# Altium schematic sheet SuperCapV2.0.SchDoc
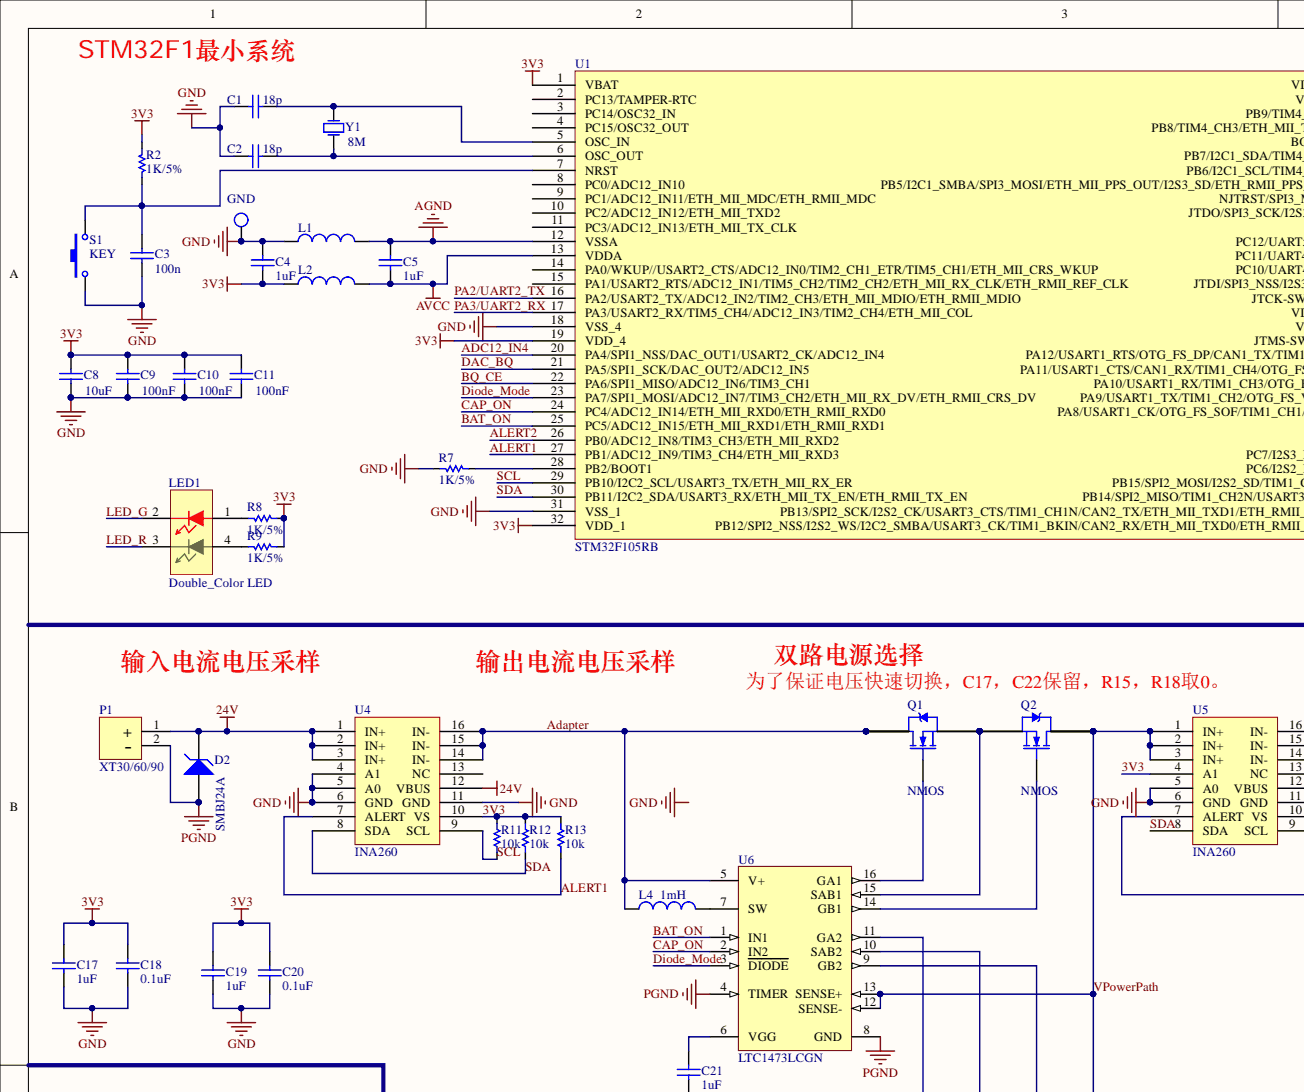
[diagram p0: SuperCapV2.0.SchDoc, top left]
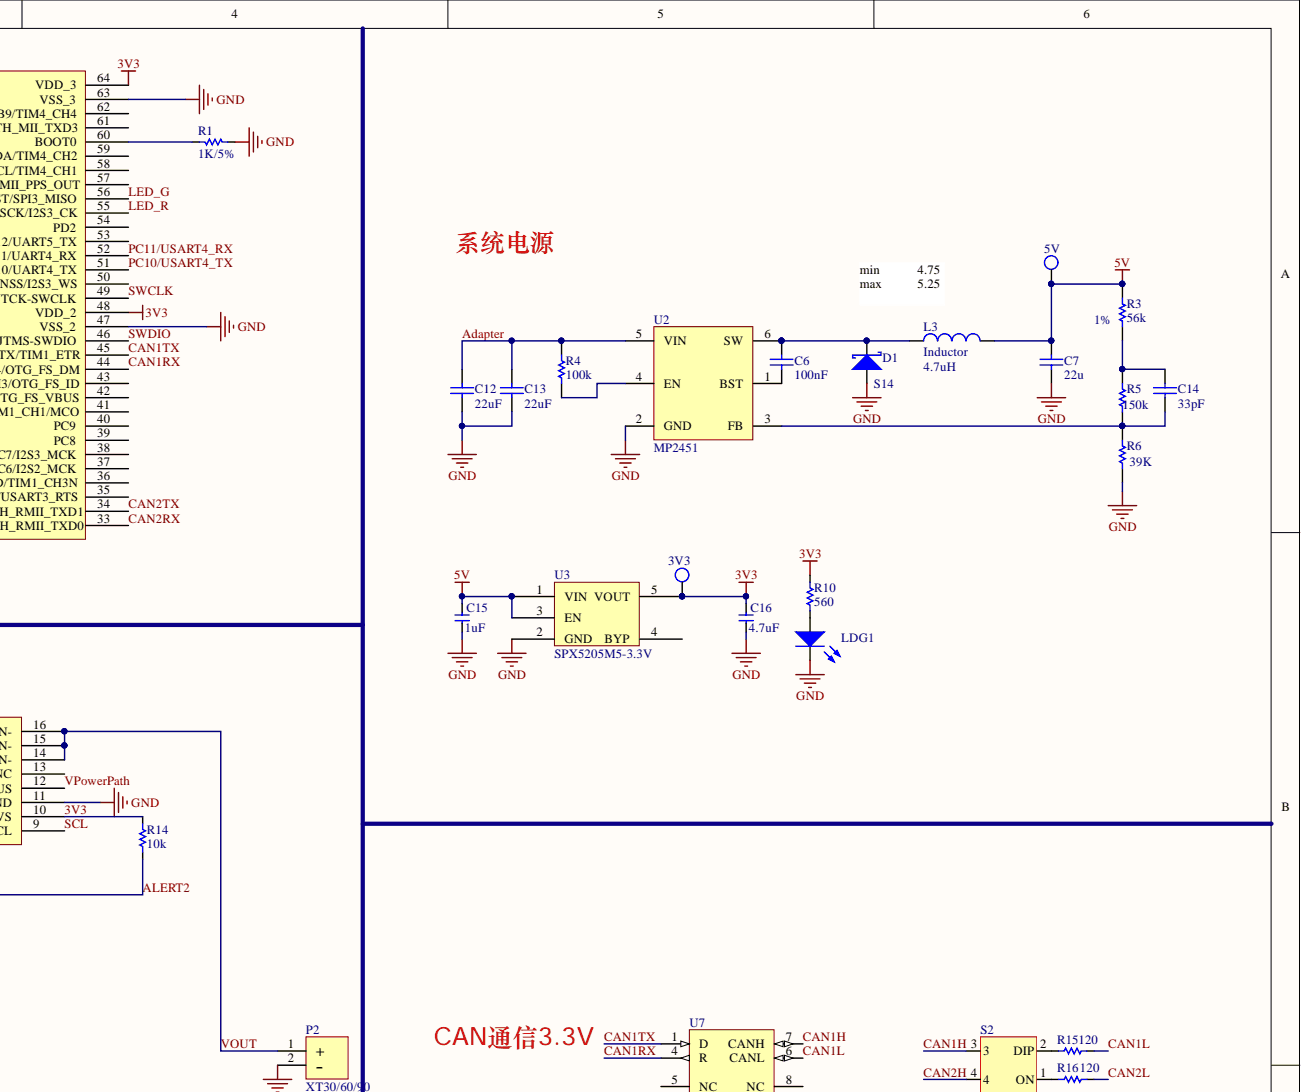
[diagram p1: SuperCapV2.0.SchDoc, top right]
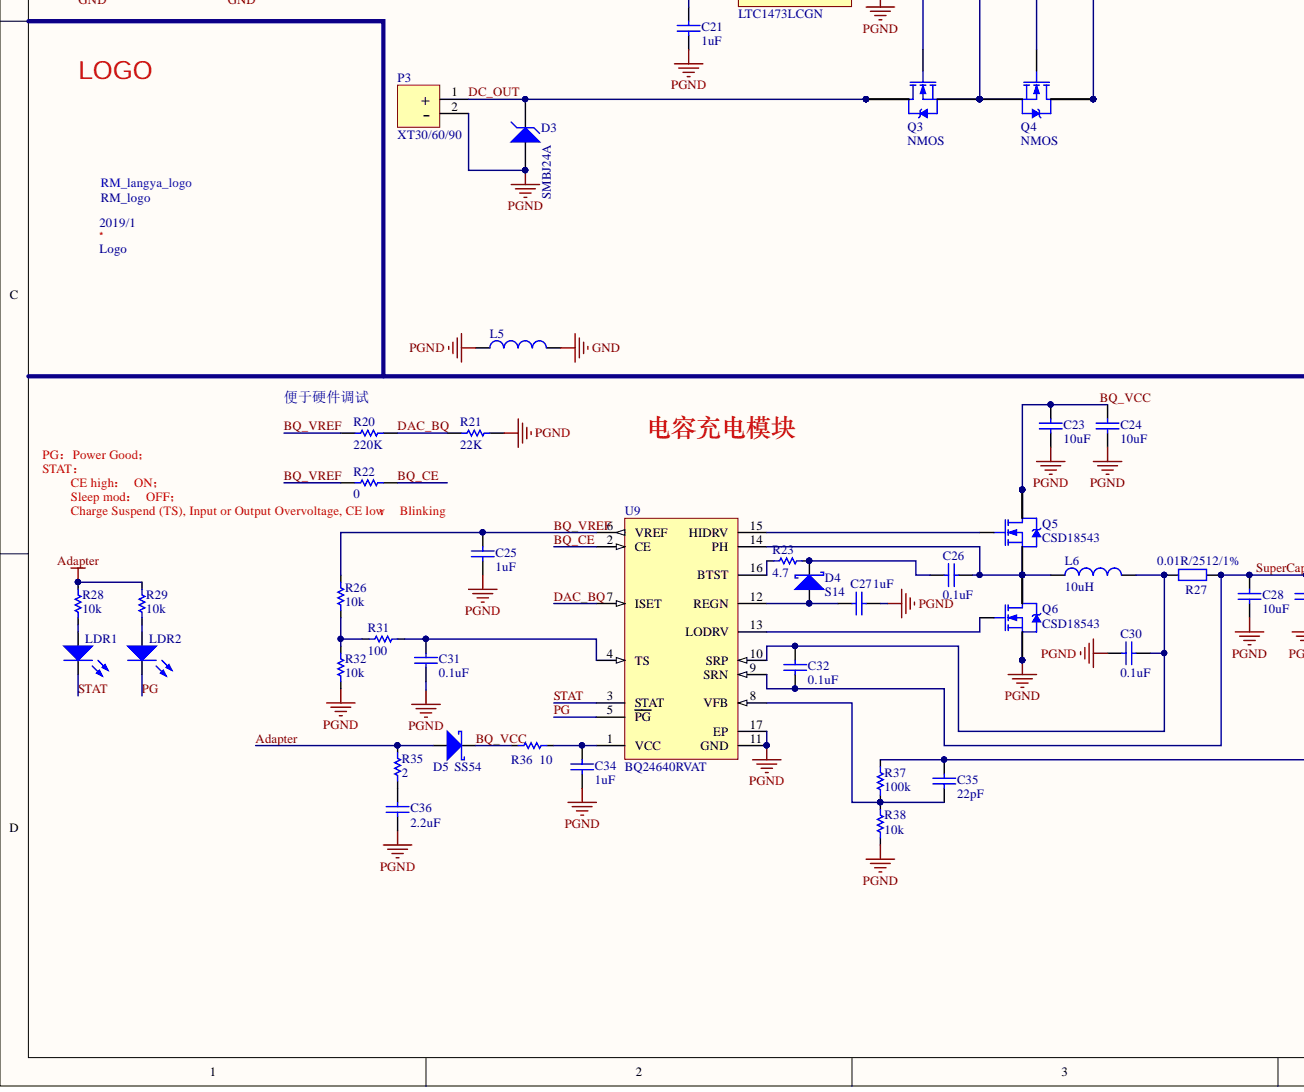
[diagram p2: SuperCapV2.0.SchDoc, bottom left]
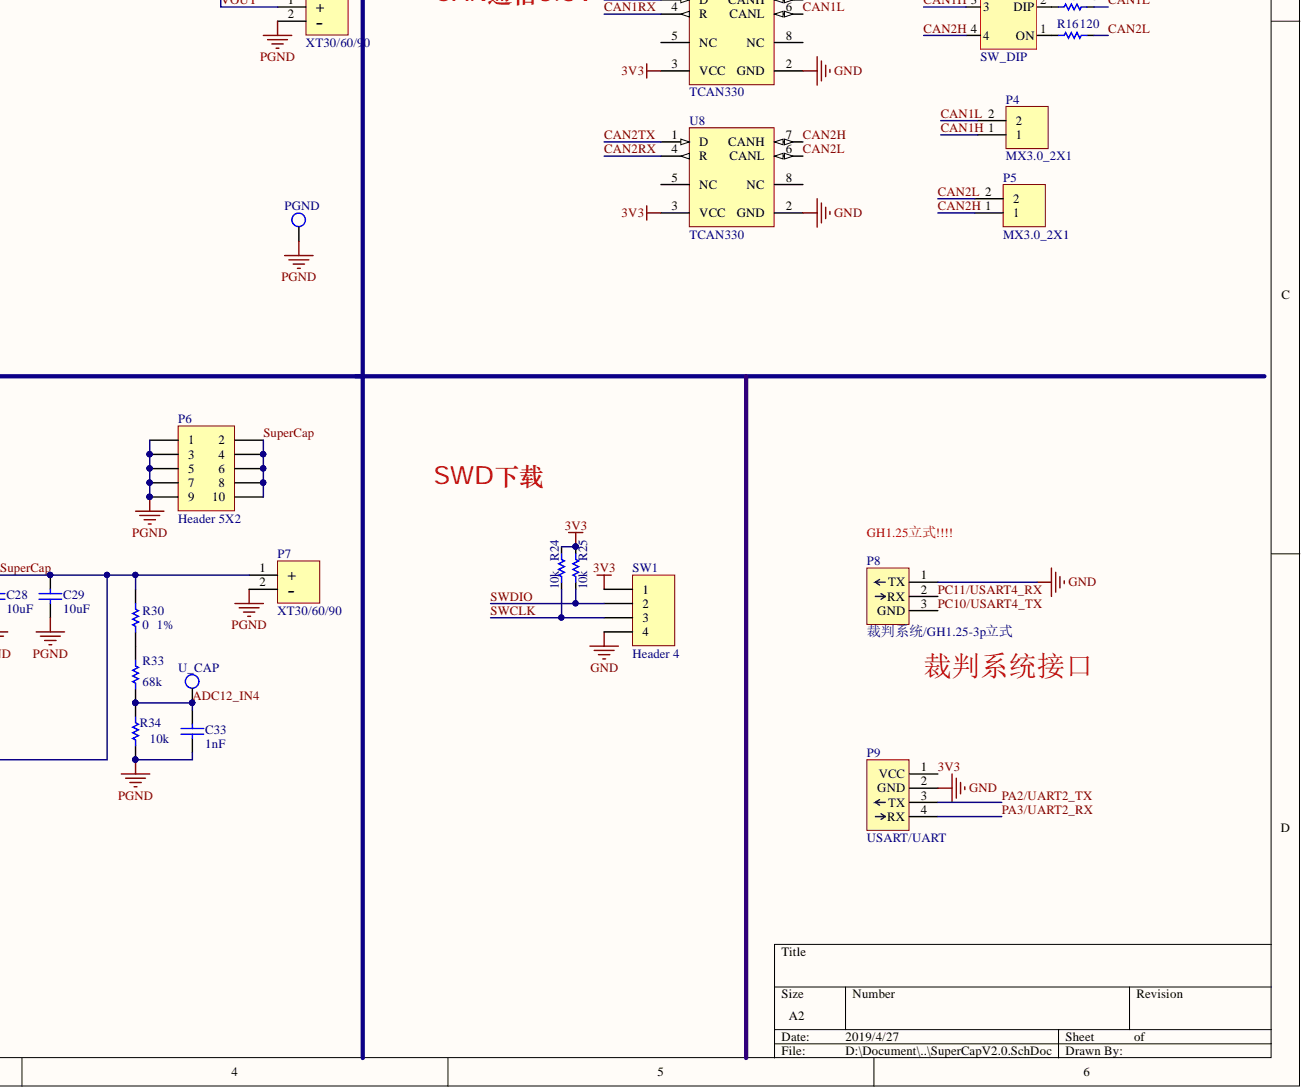
[diagram p3: SuperCapV2.0.SchDoc, bottom right]

## Parts
3V3  3V3  [TP_SMT]
5V  5V  [TP_SMT]
2019/1  Logo  [logo_langya - duplicate]
C1  value 18p  [0603C]  (hidden comment: Cap)
C2  value 18p  [0603C]  (hidden comment: Cap)
C3  value 100n  [0603C]  (hidden comment: Cap)
C4  value 1uF  [0603C]  (hidden comment: Cap)
C5  value 1uF  [0603C]  (hidden comment: Cap)
C6  value 100nF  [0603C]  (hidden comment: 1uF/0603/50V)
C7  value 22u  [0805C]  (hidden comment: 1uF/0603/50V)
C8  value 10uF  [0603C]  (hidden comment: 100nF)
C9  value 100nF  [0603C]
C10  value 100nF  [0603C]
C11  value 100nF  [0603C]
C12  value 22uF  [0805C]  (hidden comment: 1uF/0603/50V)
C13  value 22uF  [0805C]  (hidden comment: 1uF/0603/50V)
C14  value 33pF  [0603C]  (hidden comment: 1uF/0603/50V)
C15  1uF  [0603C]
C16  4.7uF  [0603C]
C17  value 1uF  [0603C]  (hidden comment: Cap)
C18  value 0.1uF  [0603C]  (hidden comment: Cap)
C19  value 1uF  [0603C]  (hidden comment: Cap)
C20  value 0.1uF  [0603C]  (hidden comment: Cap)
C21  value 1uF  [0603C]  (hidden comment: Cap)
C23  10uF  [0805]
C24  10uF  [0805]
C25  1uF  [0603C]
C26  0.1uF  [0603C]
C27  1uF  [0603C]  (value not drawn)
C28  10uF  [0805]
C29  10uF  [0805]
C30  0.1uF  [0603C]
C31  0.1uF  [0603C]
C32  0.1uF  [0603C]
C33  value 1nF  [0603C]  (hidden comment: 1uF/0603/50V)
C34  1uF  [0805]
C35  22pF  [0603C]
C36  2.2uF  [0805]
D1  S14  [SOD-323-]
D2  SMBJ24A  [SMB/DO-214AA]
D3  SMBJ24A  [SMB/DO-214AA]
D4  S14  [SOD-323-]
D5  SS54  [SMA/DO-214AC]
GND  GND  [TP_VIA]
L1  10mH/0402  [0603]  (value not drawn)
L2  10mH/0402  [0603]  (value not drawn)
L3  Inductor  value 4.7uH  [0420L]
L4  Inductor  value 1mH  [1812]
L5  10mH/0402  [0603]  (value not drawn)
L6  10uH  [XAL6060-103MEB]
LangYa1  RM_logo  [RM_logo 560mil*460]
LDG1  LED_RED  [0603LED_G]  (value not drawn)
LDR1  LED_RED  [0603LED_R]  (value not drawn)
LDR2  LED_RED  [0603LED_R]  (value not drawn)
LED1  Double_Color LED  [0805LEDX2]
P1  XT30/60/90  [XT30立式]
P2  XT30/60/90  [XT30立式]
P3  XT30/60/90  [XT30立式]
P4  MX3.0_2X1  [MX3.0MM 2X1AW]
P5  MX3.0_2X1  [MX3.0MM 2X1AW]
P6  Header 5X2  [HDR2X5]
P7  XT30/60/90  [XT30立式]
P8  裁判系统/GH1.25-3p立式  [A1254-03AWB]
P9  USART/UART  [HY2.00MM 4X1立式]
PGND  PGND  [TP_VIA]
Q1  NMOS  [SON5x6]
Q2  NMOS  [SON5x6]
Q3  NMOS  [SON5x6]
Q4  NMOS  [SON5x6]
Q5  CSD18543  [Q3A]
Q6  CSD18543  [Q3A]
R1  1K/5%  [0603R]
R2  1K/5%  [0603R]
R3  1%  value 56k  [0603R]
R4  value 100k  [0603R]  (hidden comment: Res1)
R5  value 150k  [0603R]  (hidden comment: Res1)
R6  value 39K  [0603R]  (hidden comment: Res1)
R7  1K/5%  [0603R]
R8  1K/5%  [0603R]
R9  1K/5%  [0603R]
R10  560  [0603R]
R11  10k  [0603R]
R12  10k  [0603R]
R13  10k  [0603R]
R14  10k  [0603R]
R15  value 120  [0603R]  (hidden comment: 1K/5%)
R16  value 120  [0603R]  (hidden comment: 1K/5%)
R20  value 220K  [0603R]  (hidden comment: Res1)
R21  value 22K  [0603R]  (hidden comment: Res1)
R22  value 0  [0603R]  (hidden comment: Res1)
R23  4.7  [0603R]
R24  10k  [0603R]
R25  10k  [0603R]
R26  10k  [0603R]
R27  0.01R/2512/1%  [2512]
R28  10k  [0603R]
R29  10k  [0603R]
R30  1%  value 0  [0603R]
R31  100  [0603R]
R32  10k  [0603R]
R33  value 68k  [0603R]  (hidden comment: Res1)
R34  value 10k  [0603R]  (hidden comment: Res1)
R35  2  [0603R]
R36  10  [0603R]
R37  100k  [0603R]
R38  10k  [0603R]
S1  KEY  [SW 3mmx4mm]
S2  SW_DIP  [SW_DIP_2X2]
SW1  Header 4  [HDR1X4]
U1  STM32F105RB  [LQFP_64]
U2  MP2451  [SOT23-6]
U3  SPX5205M5-3.3V  [SOT-23-5 L]
U4  INA260  [TSSOP-16]
U5  INA260  [TSSOP-16]
U6  LTC1473LCGN  [LT-GN-16_N]
U7  TCAN330  [SN65HVD232]
U8  TCAN330  [SN65HVD232]
U9  BQ24640RVAT  [BQ24640]
U_CAP  U_IN6  [TP_SMT]  (value not drawn)
Y1  8M  [NX5032GA]

## Nets
5V: 5V.1 C7.2 C15.2 L3.2 R3.2 U3.1(VIN) U3.3(EN)
24V: D2.2 P1.1 U4.1(IN+) U4.2(IN+) U4.3(IN+) U4.12(VBUS)
AGND: C5.1 L1.2 U1.12(VSSA)
AVCC: C5.2 L2.2 U1.13(VDDA)
GND: C1.2 C2.2 C3.2 C4.1 C7.1 C8.1 C9.1 C10.1 C11.1 C12.1 C13.1 C15.1 C16.1 C17.2 C18.2 C19.2 C20.2 D1.1 GND.1 L1.1 L5.2 LDG1.2 P8.1 P9.2(GND) R1.2 R6.1 R7.1 S1.2 SW1.4 U1.18(VSS_4) U1.31(VSS_1) U1.47(VSS_2) U1.63(VSS_3) U2.2(GND) U3.2(GND) U4.4(A1) U4.5(A0) U4.6(GND) U4.11(GND) U5.5(A0) U5.6(GND) U5.11(GND) U7.2(GND) U8.2(GND)
PGND: C21.2 C23.1 C24.1 C25.1 C27.1 C28.2 C29.2 C30.1 C31.1 C33.1 C34.1 C36.1 D2.1 D3.1 L5.1 P1.2 P2.2 P3.2 P6.1 P6.3 P6.5 P6.7 P6.9 P7.2 PGND.1 Q6.1 Q6.2 Q6.3 R21.1 R32.2 R34.1 R38.1 U6.4(TIMER) U6.8(GND) U9.11(GND) U9.17(EP)
3V3: 3V3.1 C4.2 C8.2 C9.2 C10.2 C11.2 C16.2 C17.1 C18.1 C19.1 C20.1 L2.1 P9.1(VCC) R2.1 R8.2 R9.2 R10.2 R11.1 R12.1 R13.1 R14.1 R24.1 R25.1 SW1.1 U1.1(VBAT) U1.19(VDD_4) U1.32(VDD_1) U1.48(VDD_2) U1.64(VDD_3) U3.5(VOUT) U4.10(VS) U5.4(A1) U5.10(VS) U7.3(VCC) U8.3(VCC)
Adapter: C12.2 C13.2 D5.1 L4.1 Q1.5 Q1.6 Q1.7 Q1.8 Q1.9 R4.2 R28.2 R29.2 R35.2 U2.5(VIN) U4.14(IN-) U4.15(IN-) U4.16(IN-) U6.5(V+)
ADC12_IN4: C33.2 R33.1 R34.2 U1.20(PA4/SPI1_NSS/DAC_OUT1/USART2_CK/ADC12_IN4) U_CAP.1
ALERT1: R13.2 U1.27(PB1/ADC12_IN9/TIM3_CH4/ETH_MII_RXD3) U4.7(ALERT)
ALERT2: R14.2 U1.26(PB0/ADC12_IN8/TIM3_CH3/ETH_MII_RXD2) U5.7(ALERT)
BAT_ON: U1.25(PC5/ADC12_IN15/ETH_MII_RXD1/ETH_RMII_RXD1) U6.1(IN1)
BQ_CE: R22.1 U1.22(PA6/SPI1_MISO/ADC12_IN6/TIM3_CH1) U9.2(CE)
BQ_VCC: C23.2 C24.2 D5.2 Q5.5 Q5.6 Q5.7 Q5.8 Q5.9 R36.1
BQ_VREF: C25.2 R20.2 R22.2 R26.1 U9.6(VREF)
CAN1H: P4.1 S2.3 U7.7(CANH)
CAN1L: P4.2 R15.1 U7.6(CANL)
CAN1RX: U1.44(PA11/USART1_CTS/CAN1_RX/TIM1_CH4/OTG_FS_DM) U7.4(R)
CAN1TX: U1.45(PA12/USART1_RTS/OTG_FS_DP/CAN1_TX/TIM1_ETR) U7.1(D)
CAN2H: P5.1 S2.4 U8.7(CANH)
CAN2L: P5.2 R16.1 U8.6(CANL)
CAN2RX: U1.33(PB12/SPI2_NSS/I2S2_WS/I2C2_SMBA/USART3_CK/TIM1_BKIN/CAN2_RX/ETH_MII_TXD0/ETH_RMII_TXD0) U8.4(R)
CAN2TX: U1.34(PB13/SPI2_SCK/I2S2_CK/USART3_CTS/TIM1_CH1N/CAN2_TX/ETH_MII_TXD1/ETH_RMII_TXD1) U8.1(D)
CAP_ON: U1.24(PC4/ADC12_IN14/ETH_MII_RXD0/ETH_RMII_RXD0) U6.2(IN2)
DAC_BQ: R20.1 R21.2 U1.21(PA5/SPI1_SCK/DAC_OUT2/ADC12_IN5) U9.7(ISET)
DC_OUT: D3.2 P3.1 Q3.5 Q3.6 Q3.7 Q3.8 Q3.9
Diode_Mode: U1.23(PA7/SPI1_MOSI/ADC12_IN7/TIM3_CH2/ETH_MII_RX_DV/ETH_RMII_CRS_DV) U6.3(DIODE)
LED_G: LED1.2 U1.56(NJTRST/SPI3_MISO)
LED_R: LED1.3 U1.55(JTDO/SPI3_SCK/I2S3_CK)
PA2/UART2_TX: P9.3(TX) U1.16(PA2/USART2_TX/ADC12_IN2/TIM2_CH3/ETH_MII_MDIO/ETH_RMII_MDIO)
PA3/UART2_RX: P9.4(RX) U1.17(PA3/USART2_RX/TIM5_CH4/ADC12_IN3/TIM2_CH4/ETH_MII_COL)
PC10/USART4_TX: P8.3 U1.51(PC10/UART4_TX)
PC11/USART4_RX: P8.2 U1.52(PC11/UART4_RX)
PG: LDR2.2 U9.5(PG)
SCL: R11.2 U1.29(PB10/I2C2_SCL/USART3_TX/ETH_MII_RX_ER) U4.9(SCL) U5.9(SCL)
SDA: R12.2 U1.30(PB11/I2C2_SDA/USART3_RX/ETH_MII_TX_EN/ETH_RMII_TX_EN) U4.8(SDA) U5.8(SDA)
STAT: LDR1.2 U9.3(STAT)
SuperCap: C28.1 C29.1 C32.1 C35.2 P6.2 P6.4 P6.6 P6.8 P6.10 P7.1 R27.1 R30.2 R37.2 U9.9(SRN)
SWCLK: R24.2 SW1.3 U1.49(JTCK-SWCLK)
SWDIO: R25.2 SW1.2 U1.46(JTMS-SWDIO)
VOUT: P2.1 U5.14(IN-) U5.15(IN-) U5.16(IN-)
VPowerPath: Q2.5 Q2.6 Q2.7 Q2.8 Q2.9 Q4.5 Q4.6 Q4.7 Q4.8 Q4.9 U5.1(IN+) U5.2(IN+) U5.3(IN+) U5.12(VBUS) U6.12(SENSE-) U6.13(SENSE+)
NetC1_1: C1.1 U1.5(OSC_IN) Y1.2
NetC2_1: C2.1 U1.6(OSC_OUT) Y1.1
NetC3_1: C3.1 R2.2 S1.1 U1.7(NRST)
NetC6_1: C6.1 U2.1(BST)
NetC6_2: C6.2 D1.2 L3.1 U2.6(SW)
NetC14_1: C14.1 R5.1 R6.2 U2.3(FB)
NetC14_2: C14.2 R3.1 R5.2
NetC21_1: C21.1 U6.6(VGG)
NetC26_1: C26.1 L6.1 Q5.1 Q5.2 Q5.3 Q6.5 Q6.6 Q6.7 Q6.8 Q6.9 U9.14(PH)
NetC26_2: C26.2 D4.2 R23.2
NetC27_2: C27.2 D4.1 U9.12(REGN)
NetC30_2: C30.2 C32.2 L6.2 R27.2 U9.10(SRP)
NetC31_2: C31.2 R31.2 U9.4(TS)
NetC34_2: C34.2 R36.2 U9.1(VCC)
NetC35_1: C35.1 R37.1 R38.2 U9.8(VFB)
NetC36_2: C36.2 R35.1
NetL4_2: L4.2 U6.7(SW)
NetLDG1_1: LDG1.1 R10.1
NetLDR1_1: LDR1.1 R28.1
NetLDR2_1: LDR2.1 R29.1
NetLED1_1: LED1.1 R8.1
NetLED1_4: LED1.4 R9.1
NetQ1_1: Q1.1 Q1.2 Q1.3 Q2.1 Q2.2 Q2.3 U6.15(SAB1)
NetQ1_4: Q1.4 U6.16(GA1)
NetQ2_4: Q2.4 U6.14(GB1)
NetQ3_1: Q3.1 Q3.2 Q3.3 Q4.1 Q4.2 Q4.3 U6.10(SAB2)
NetQ3_4: Q3.4 U6.11(GA2)
NetQ4_4: Q4.4 U6.9(GB2)
NetQ5_4: Q5.4 U9.15(HIDRV)
NetQ6_4: Q6.4 U9.13(LODRV)
NetR1_1: R1.1 U1.60(BOOT0)
NetR4_1: R4.1 U2.4(EN)
NetR7_2: R7.2 U1.28(PB2/BOOT1)
NetR15_2: R15.2 S2.2(DIP)
NetR16_2: R16.2 S2.1(ON)
NetR23_1: R23.1 U9.16(BTST)
NetR26_2: R26.2 R31.1 R32.1
NetR30_1: R30.1 R33.2

Not connected: U1 2(PC13/TAMPER-RTC) 3(PC14/OSC32_IN) 4(PC15/OSC32_OUT) 8(PC0/ADC12_IN10) 9(PC1/ADC12_IN11/ETH_MII_MDC/ETH_RMII_MDC) 10(PC2/ADC12_IN12/ETH_MII_TXD2) 11(PC3/ADC12_IN13/ETH_MII_TX_CLK) 14(PA0/WKUP//USART2_CTS/ADC12_IN0/TIM2_CH1_ETR/TIM5_CH1/ETH_MII_CRS_WKUP) 15(PA1/USART2_RTS/ADC12_IN1/TIM5_CH2/TIM2_CH2/ETH_MII_RX_CLK/ETH_RMII_REF_CLK) 35(PB14/SPI2_MISO/TIM1_CH2N/USART3_RTS) 36(PB15/SPI2_MOSI/I2S2_SD/TIM1_CH3N) 37(PC6/I2S2_MCK) 38(PC7/I2S3_MCK) 39(PC8) 40(PC9) 41(PA8/USART1_CK/OTG_FS_SOF/TIM1_CH1/MCO) 42(PA9/USART1_TX/TIM1_CH2/OTG_FS_VBUS) 43(PA10/USART1_RX/TIM1_CH3/OTG_FS_ID) 50(JTDI/SPI3_NSS/I2S3_WS) 53(PC12/UART5_TX) 54(PD2) 57(PB5/I2C1_SMBA/SPI3_MOSI/ETH_MII_PPS_OUT/I2S3_SD/ETH_RMII_PPS_OUT) 58(PB6/I2C1_SCL/TIM4_CH1) 59(PB7/I2C1_SDA/TIM4_CH2) 61(PB8/TIM4_CH3/ETH_MII_TXD3) 62(PB9/TIM4_CH4); U3 4(BYP); U4 13(NC); U5 13(NC); U7 5(NC) 8(NC); U8 5(NC) 8(NC)

## Library data of the parts
3V3 5V GND PGND U_CAP = TP: Component Kind: Standard; Library Name: MyComponents.SchLib
C1-C14 C17-C21 C33 = Cap: Capacitor; Component Kind: Standard; LatestRevisionDate: 17-Jul-2002; LatestRevisionNote: Re-released for DXP Platform.; PackageDescription: Radial Cap, Thru-Hole; 2 Leads; 0.3 in Pin Spacing; Published: 8-Jun-2000; Signal Integrity: Cap; Simulation: CAP; Publisher: Altium Limited
  C1 C2 C4 C5 C17-C21: Library Name: Miscellaneous Devices.IntLib; PackageReference: RAD-0.3
  C3: Library Name: Miscellaneous Devices.IntLib; PackageReference: 0603C
  C6 C7 C12-C14 C33: Library Name: MyComponents.IntLib; PackageReference: RAD-0.3
  C8-C11: Library Name: MyComponents.SchLib; PackageReference: RAD-0.3
C15 C16 = Cap: Value: 100nF; Component Kind: Standard; Library Name: WolferWu.SchLib
C23-C32 C34-C36 = Cap: Capacitor; Value: 100pF; Component Kind: Standard; LatestRevisionDate: 17-Jul-2002; LatestRevisionNote: Re-released for DXP Platform.; Library Name: MyComponents_v2.4.SchLib; PackageDescription: Radial Cap, Thru-Hole; 2 Leads; 0.3 in Pin Spacing; PackageReference: RAD-0.3; Published: 8-Jun-2000; Signal Integrity: Cap; Simulation: CAP; Publisher: Altium Limited
D1 D4 D5 = D Schottky: Schottky Diode; Code_JEDEC: DO-214-AA; Component Kind: Standard; LatestRevisionDate: 17-Jul-2002; LatestRevisionNote: Re-released for DXP Platform.; PackageDescription: DO-214-AA/SMB; 2 C-Bend Leads; Body 5.3 x 3.6 mm, inc. leads (LxW); PackageReference: SMB; Published: 8-Jun-2000; Signal Integrity: D Schottky; Simulation: SKYDIODE; Publisher: Altium Limited
  D1 D4: Library Name: MyComponents.IntLib
  D5: Library Name: MyComponents_v2.4.SchLib
D2 D3 = D Zener: Zener Diode; Component Kind: Standard; LatestRevisionDate: 17-Jul-2002; LatestRevisionNote: Re-released for DXP Platform.; Library Name: MyComponents.IntLib; PackageDescription: Diode, Thru-Hole; 2 Leads; 0.7 in Pin Spacing; PackageReference: DIODE-0.7; Published: 8-Jun-2000; Signal Integrity: D Zener; Simulation: ZENER; Publisher: Altium Limited
L1 L2 L5 L6 = Inductor: Code_IEC: 1005; Value: 10mH; Code_JEITA: 0402; Component Kind: Standard; Description: Inductor; LatestRevisionDate: 29-May-2009; LatestRevisionNote: IPC-7351 Footprint Added.; PackageDescription: Chip Inductor; PackageReference: 0402-A; Published: 8-Jun-2000; Signal Integrity: Inductor; Simulation: INDUCTOR; Publisher: Altium Limited
  L1 L2 L5: Library Name: MyComponents.IntLib
  L6: Library Name: MyComponents_v2.4.SchLib
L3 L4 = Inductor: Code_IEC: 1005; Code_JEITA: 0402; Component Kind: Standard; Description: Inductor; LatestRevisionDate: 29-May-2009; LatestRevisionNote: IPC-7351 Footprint Added.; Library Name: Miscellaneous Devices.IntLib; PackageDescription: Chip Inductor; PackageReference: 0402-A; Published: 8-Jun-2000; Signal Integrity: Inductor; Simulation: INDUCTOR; Publisher: Altium Limited
LDG1 LDR1 LDR2 = LED: Typical RED, GREEN, YELLOW, AMBER GaAs LED; Component Kind: Standard; LatestRevisionDate: 17-Jul-2002; LatestRevisionNote: Re-released for DXP Platform.; PackageDescription: SM LED; 2 Flat Leads; PackageReference: 3.2X1.6X1.1; PackageVersion: Feb-2002; Published: 8-Jun-2000; Simulation: LED2; Publisher: Altium Limited
  LDG1: Library Name: MyComponents.SchLib
  LDR1 LDR2: Library Name: MyComponents_v2.4.SchLib
P6 = Header 5X2: Header, 5-Pin, Dual row; Component Kind: Standard; LatestRevisionDate: 17-Jul-2002; LatestRevisionNote: Re-released for DXP Platform.; Library Name: Miscellaneous Connectors.IntLib; Signal Integrity: Connector; Publisher: Altium Limited
P8 = UART_3pin: Component Kind: Standard; Library Name: MyComponents_v2.5.IntLib
P9 = UART_4pin: 带电源串口; Component Kind: Standard; Library Name: MyComponents_v2.4.SchLib
Q1-Q6 = NMOS: SON 5x6; Component Kind: Standard
  Q1-Q4: Library Name: MyComponents_v2.4.SchLib
  Q5 Q6: Library Name: MyComponents_v2.5.SchLib
R1 R2 R7-R14 R23-R26 R28 R29 R31 R32 R35-R38 = Res1: Resistor; Value: 1K; Component Kind: Standard; LatestRevisionDate: 17-Jul-2002; LatestRevisionNote: Re-released for DXP Platform.; PackageDescription: Axial Device, Thru-Hole; 2 Leads; 0.3 in Pin Spacing; PackageReference: AXIAL-0.3; Published: 8-Jun-2000; Signal Integrity: Res; Simulation: RESISTOR; Publisher: Altium Limited
  R1 R2 R7-R9: Library Name: MyComponents.IntLib
  R10-R14 R24 R25: Library Name: MyComponents.SchLib
  R23 R26 R28 R29 R31 R32 R35-R38: Library Name: MyComponents_v2.4.SchLib
R3-R6 R15 R16 R20-R22 R30 R33 R34 = Res1: Resistor; Component Kind: Standard; LatestRevisionDate: 17-Jul-2002; LatestRevisionNote: Re-released for DXP Platform.; PackageDescription: Axial Device, Thru-Hole; 2 Leads; 0.3 in Pin Spacing; PackageReference: AXIAL-0.3; Published: 8-Jun-2000; Signal Integrity: Res; Simulation: RESISTOR; Publisher: Altium Limited
  R3-R6 R20-R22 R30 R33 R34: Library Name: Miscellaneous Devices.IntLib
  R15 R16: Library Name: MyComponents.IntLib
R27 = Res2: Resistor; Value: 1K; Component Kind: Standard; LatestRevisionDate: 17-Jul-2002; LatestRevisionNote: Re-released for DXP Platform.; Library Name: AllSCH.SchLib; PackageDescription: Axial Device, Thru-Hole; 2 Leads; 0.4 in Pin Spacing; PackageReference: AXIAL-0.4; Published: 8-Jun-2000; Signal Integrity: Res; Simulation: RESISTOR; Publisher: Altium Limited
S1 = PushButton_2p: Button; Component Kind: Standard; LatestRevisionDate: 17-Jul-2002; LatestRevisionNote: Re-released for DXP Platform.; Library Name: MyComponents.IntLib; PackageDescription: Switch; 2 Leads; PackageReference: SPST-2; Published: 24-Mar-1999; Publisher: Altium Limited
SW1 = Header 4: Header, 4-Pin; Component Kind: Standard; LatestRevisionDate: 17-Jul-2002; LatestRevisionNote: Re-released for DXP Platform.; Library Name: Miscellaneous Connectors.IntLib; Signal Integrity: Connector; Publisher: Altium Limited
U2 = MP2451: 开关电源0.6A; Component Kind: Standard; Library Name: MyComponents.IntLib
U4 U5 = INA260: Precision Digital Current and Power Monitor With Low-Drift, Precision Integrated Shunt; Component Kind: Standard; Library Name: mylib.SchLib
U6 = CMP-0479-00093-1: Dual Low Voltage PowerPath Switch Driver, 4.75 to 30 V Vin, 16-pin SOP (GN-16), 0 to 70 degC; Manufacturer: Linear Technology; Ideal Diode: Dual, External, N-Channel; Component Kind: Standard; ComponentLink1Description: Manufacturer URL; ComponentLink1URL: http://www.linear.com/; ComponentLink2Description: Datasheet; ComponentLink2URL: http://cds.linear.com/docs/en/datasheet/1473lf.pdf; ComponentLink3Description: Package Specification; ComponentLink3URL: http://cds.linear.com/docs/en/packaging/05081641_B_GN16.pdf; Library Name: Altium Content Vault; Mounting Technology: Surface Mount; PackageDescription: 16-Pin Plastic SSOP, Body 4.9 x 3.9 mm, Pitch 0.635 mm; PackageReference: GN-16; PackageVersion: Rev. B, 03/2012; PartNumber: LTC1473LCGN; RoHS: FALSE
U7 U8 = SN65HVD232D: 3.3 V CAN Transceiver, 17 mA, -40 to 85 degC, 8-pin SOIC (D), Green (RoHS & no Sb/Br); Manufacturer: Texas Instruments; Drivers Per Package: 1; ICC(Max)(mA): 17; Rating: Catalog; Supply Voltage(s)(V): 3.3; Transient Pulse Protection(V): -25 to 25; Code_JEDEC: MS-012AA; Component Kind: Standard; ComponentLink1Description: Datasheet; ComponentLink1URL: http://www.ti.com/general/docs/lit/getliterature.tsp?genericPartNumber=SN65HVD232&fileType=pdf; DatasheetVersion: SLOS346K; Eco-Plan: Green (RoHS & no Sb/Br); Isolated: No; Library Name: Texas Instruments Interface CAN.IntLib; Mounting Technology: Surface Mount; PackageDescription: 8-Pin Plastic Small Outline Integrated Circuit, 4.9 x 3.9 mm, Pitch 1.27 mm Pitch; PackageReference: D8; PackageVersion: revM, Jun-2011; PartNumber: SN65HVD232D; Receivers Per Package: 1; SubFamily: CAN; Supplier 1: Digi-Key; Supplier 2: Farnell; Supplier 3: Newark; Supplier 4: Mouser; Supplier Part Number 1: 296-12282-5-ND; Supplier Part Number 2: 1103107; Supplier Part Number 3: 35K4890; Supplier Part Number 4: 595-SN65HVD232D
U9 = BQ24640RVAT: High Efficiency Synchronous Switch-Mode Battery Charge Controller for Super Capacitor, 5 V, -40 to 85 degC, 16-pin VQFN (RVA), Green (RoHS & no Sb/Br); Manufacturer: Texas Instruments; Lead Acid: N; SubFamily: Battery Charge Management; Vin(Min)(V): 5; Component Kind: Standard; ComponentLink1Description: Datasheet; ComponentLink1URL: http://www.ti.com/general/docs/lit/getliterature.tsp?genericPartNumber=BQ24640&fileType=pdf; DatasheetVersion: SLUSA44; Eco-Plan: Green (RoHS & no Sb/Br); Li-Ion: N; Li-Polymer: N; Library Name: TI Power Management Battery Management.IntLib; Mounting Technology: Surface Mount; NiCd: N; NiMH: N; Over Voltage Protection: N; PackageDescription: 16-Pin Plastic Quad Flatpack No-Lead, 3.5 x 3.5 mm Body, 0.5 mm Pitch, Exposed Pad; PackageReference: RVA16-2140X2140TP; PackageVersion: revC, May-2011; PartNumber: BQ24640RVAT; Supplier 1: Digi-Key; Supplier 2: Digi-Key; Supplier 3: Digi-Key; Supplier 4: Newark; Supplier 5: Mouser; Supplier Part Number 1: 296-32032-2-ND; Supplier Part Number 2: 296-32032-1-ND; Supplier Part Number 3: 296-32032-6-ND; Supplier Part Number 4: 74R6083; Supplier Part Number 5: 595-BQ24640RVAT
Y1 = XTAL: Crystal Oscillator; Component Kind: Standard; LatestRevisionDate: 17-Jul-2002; LatestRevisionNote: Re-released for DXP Platform.; Library Name: MyComponents.IntLib; PackageDescription: Crystal, Thru-Hole; 2 Leads; Body 3.1 x 8.2 mm (Dia.xH); PackageReference: R38; Published: 8-Jun-2000; Simulation: XTAL; Publisher: Altium Limited
2019/1 = Logo: Component Kind: Standard; Library Name: MyComponents_v2.4.SchLib
LangYa1 = RM_logo: Component Kind: Standard; Library Name: MyComponents_v2.5.SchLib
LED1 = Double_Color LED: Component Kind: Standard; Library Name: MyComponents_v2.5.SchLib
P1-P3 P7 = XT30/60/90: Component Kind: Standard; Library Name: MyComponents_v2.4.SchLib
P4 P5 = MX3.0_2X1: Component Kind: Standard; Library Name: MyComponents.SchLib
S2 = SW_DIP: Component Kind: Standard; Library Name: MyComponents.SchLib
U1 = STM32F105RB: Component Kind: Standard; Library Name: MyComponents_v2.4.SchLib
U3 = SPX5205M5-3.3V: Component Kind: Standard; Library Name: MyComponents.SchLib
